# Altium schematic sheet RTC.SchDoc
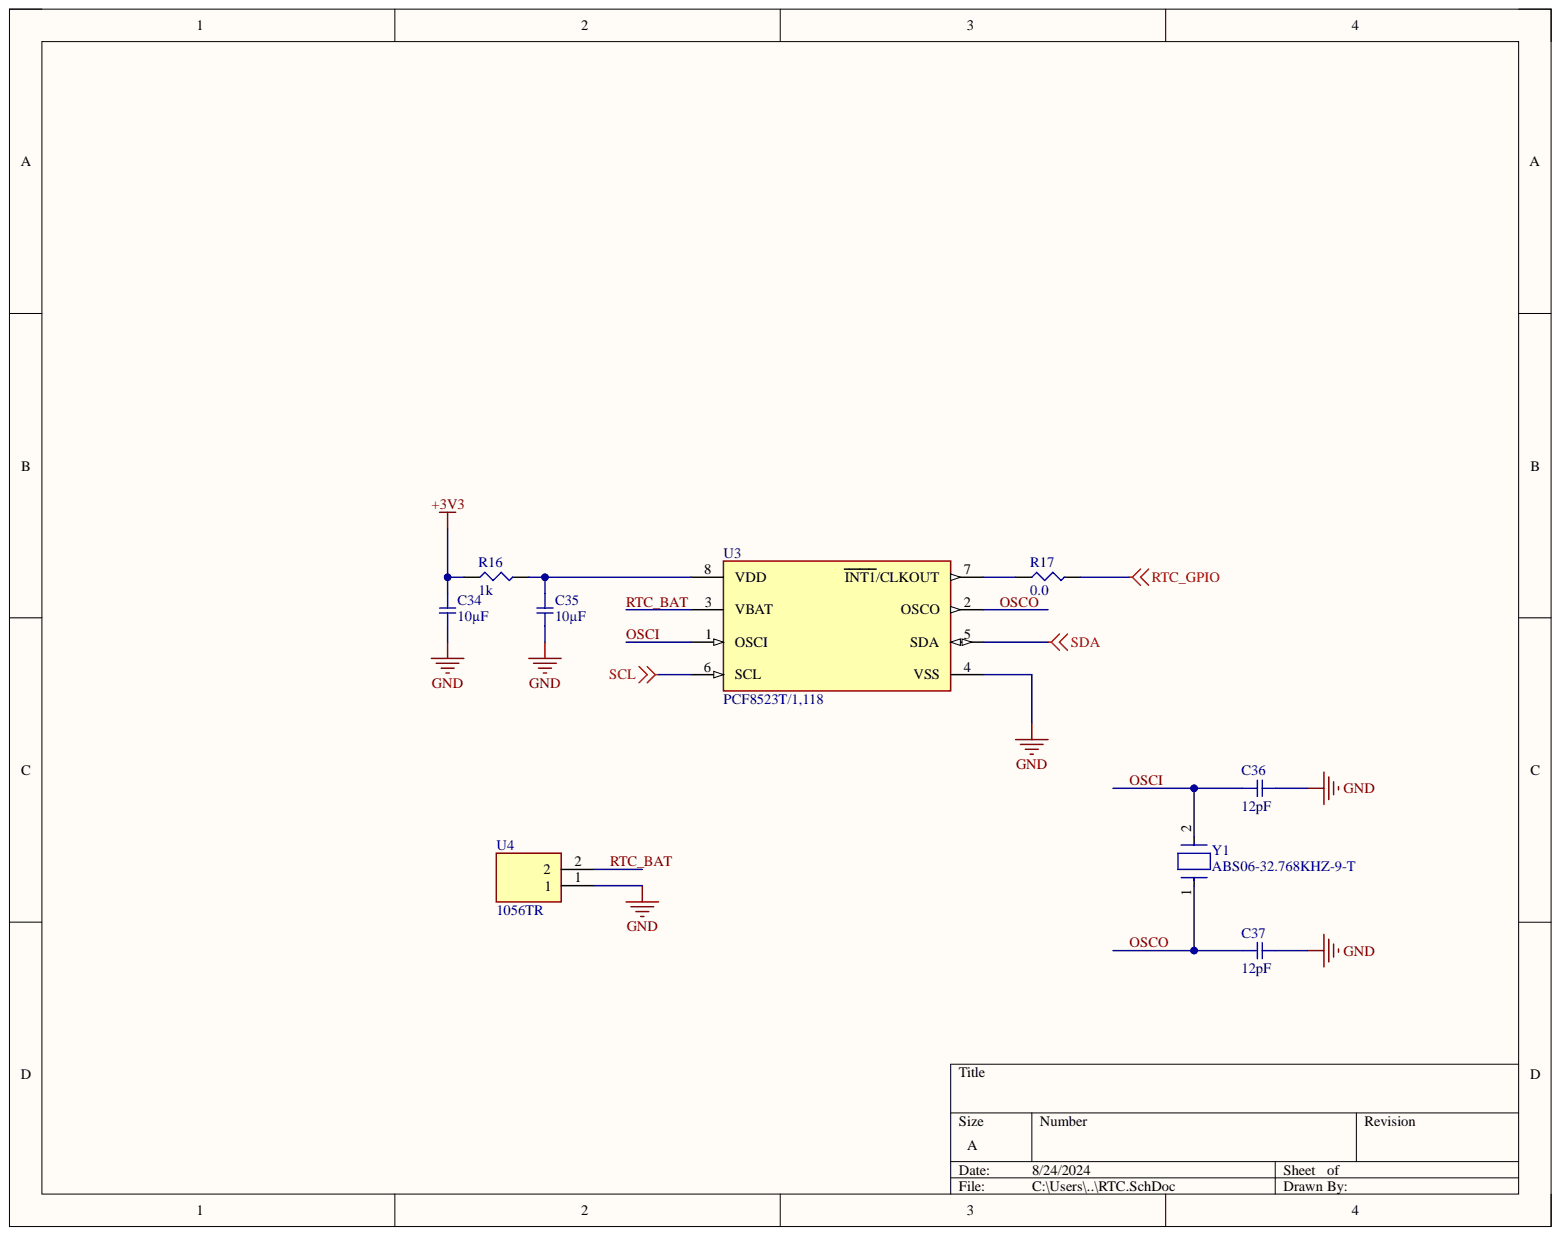
[diagram p0: RTC.SchDoc]

## Parts
C34  10µF  [SMD-0402C]
C35  10µF  [SMD-0402C]
C36  12pF  [SMD-0402C]
C37  12pF  [SMD-0402C]
R16  1k  [SMD-0402-RES]
R17  0.0  [SMD-0402-RES]
U3  PCF8523T/1,118  [FP-SOT96-1-IPC_B]
U4  1056TR  [1056TR]
Y1  ABS06-32.768KHZ-9-T  [FP-ABS06-MFG]

## Nets
+3V3: C34.1 R16.1
GND: C34.2 C35.2 C36.2 C37.2 U3.4(VSS) U4.1
RTC_GPIO: R17.2
SCL: U3.6(SCL)
SDA: U3.5(SDA)
OSCI: C36.1 U3.1(OSCI) Y1.2
OSCO: C37.1 U3.2(OSCO) Y1.1
RTC_BAT: U3.3(VBAT) U4.2
NetC35_1: C35.1 R16.2 U3.8(VDD)
NetR17_1: R17.1 U3.7(INT1/CLKOUT)

## Library data of the parts
C34 C35 = GRJ155R60J106ME11D: CAP CER 10UF 6.3V X5R 0402; Manufacturer: Murata Electronics North America; @ qty: 0; Minimum Quantity: 1; Tolerance: ±20%; Unit Price (USD): 0.23; Applications: Boardflex Sensitive; Component Kind: Standard; Datasheets: http://psearch.en.murata.com/capacitor/product/GRJ155R60J106ME11%23.pdf; Digi-Key Part Number: 490-13211-1-ND; Factory Stock: 0; Features: Derating Recommended, Soft Termination; Height - Seated (Max): -; Image: //media.digikey.com/Renders/Murata%20Renders/ZRB-SERIES-0402.jpg; Lead Spacing: -; Lead Style: -; Library Name: capacitor_murata_0402.IntLib; Mounting Type: Surface Mount, MLCC; Operating Temperature: -55°C ~ 85°C; Package / Case: 0402 (1005 Metric); Packaging: Cut Tape (CT); Part Number: GRJ155R60J106ME11D; Part Status: Active; Quantity Available: 64064; Ratings: -; Series: GRJ; Size / Dimension: 0.039" L x 0.020" W (1.00mm x 0.50mm); Temperature Coefficient: X5R; Thickness (Max): 0.028" (0.70mm); Value: 10µF; Voltage: 6.3V
C36 C37 = GCM1555C1H120JA16D: CAP CER 12PF 50V NP0 0402; Manufacturer: Murata Electronics North America; @ qty: 0; Minimum Quantity: 1; Temperature Coefficient: C0G, NP0; Tolerance: ±5%; Unit Price (USD): 0.1; Applications: Automotive; Component Kind: Standard; Datasheets: http://www.murata.com/~/media/webrenewal/support/library/catalog/products/capacitor/mlcc/c03e.ashx; Digi-Key Part Number: 490-12649-1-ND; Factory Stock: 0; Features: -; Height - Seated (Max): -; Image: //media.digikey.com/Renders/Murata%20Renders/ZRB-SERIES-0402.jpg; Lead Spacing: -; Lead Style: -; Library Name: capacitor_murata_0402.IntLib; Mounting Type: Surface Mount, MLCC; Operating Temperature: -55°C ~ 125°C; Package / Case: 0402 (1005 Metric); Packaging: Cut Tape (CT); Part Number: GCM1555C1H120JA16D; Part Status: Active; Quantity Available: 29045; Ratings: AEC-Q200; Series: GCM; Size / Dimension: 0.039" L x 0.020" W (1.00mm x 0.50mm); Thickness (Max): 0.022" (0.55mm); Value: 12pF; Voltage: 50V
R16 = ERJ-2GEJ102X: RES SMD 1K OHM 5% 1/10W 0402; Manufacturer: Panasonic Electronic Components; @ qty: 1500; Factory Stock: 0; Minimum Quantity: 1; Power (Watts): 0.1W, 1/10W; Temperature Coefficient: ±200ppm/°C; Unit Price (USD): 0.00350; Component Kind: Standard; Composition: Thick Film; Datasheets: http://industrial.panasonic.com/www-cgi/jvcr13pz.cgi?E+PZ+3+AOA0001+ERJ2GEJ102X+7+WW; Digi-Key Part Number: P1.0KJCT-ND; Failure Rate: -; Features: Automotive AEC-Q200; Height - Seated (Max): 0.016" (0.40mm); Image: //media.digikey.com/Photos/Panasonic%20Photos/ERJ-PA2-Series.jpg; Library Name: Panasonic_ERJ_0402.IntLib; Number of Terminations: 2; Operating Temperature: -55°C ~ 155°C; Package / Case: 0402 (1005 Metric); Packaging: Cut Tape (CT); Part Number: ERJ-2GEJ102X; Part Status: Active; Quantity Available: 1939857; Series: ERJ; Size / Dimension: 0.039" L x 0.020" W (1.00mm x 0.50mm); Supplier Device Package: 0402; Tolerance: ±5%; Value: 1k
R17 = ERJ-2GE0R00X: RES SMD 0.0OHM JUMPER 1/10W 0402; Manufacturer: Panasonic Electronic Components; @ qty: 1500; Factory Stock: 0; Minimum Quantity: 1; Power (Watts): 0.1W, 1/10W; Unit Price (USD): 0.00384; Component Kind: Standard; Composition: Thick Film; Datasheets: http://industrial.panasonic.com/www-cgi/jvcr13pz.cgi?E+PZ+3+AOA0001+ERJ2GE0R00X+7+WW; Digi-Key Part Number: P0.0JCT-ND; Failure Rate: -; Features: Automotive AEC-Q200; Height - Seated (Max): 0.016" (0.40mm); Image: //media.digikey.com/Photos/Panasonic%20Photos/ERJ-PA2-Series.jpg; Library Name: Panasonic_ERJ_0402.IntLib; Number of Terminations: 2; Operating Temperature: -55°C ~ 155°C; Package / Case: 0402 (1005 Metric); Packaging: Cut Tape (CT); Part Number: ERJ-2GE0R00X; Part Status: Active; Quantity Available: 3152483; Series: ERJ; Size / Dimension: 0.039" L x 0.020" W (1.00mm x 0.50mm); Supplier Device Package: 0402; Temperature Coefficient: -; Tolerance: Jumper; Value: 0.0
U3 = CMP-10083-000014-1: IC RTC CLK/CALENDAR I2C 8-SOIC; Logic Function: Clock; Max Supply Voltage: 5.5V; Min Supply Voltage: 1.2V; Time Format: HH:MM:SS; Case/Package: SO; Component Kind: Standard; Contact Plating: Gold; Interface: I2C; Lead Free: Lead Free; Library Name: Altium Content Vault; Max Operating Temperature: 85°C; Min Operating Temperature: -40°C; Mount: Surface Mount; Number of Pins: 8; Package Quantity: 2500; Packaging: Tape and Reel; Radiation Hardening: No; REACH SVHC: No SVHC
U4 = 1056TR: Undefined or Miscellaneous; Manufacturer_Name: Keystone Electronics; Component Kind: Standard; Datasheet Link: http://www.keyelco.com/product-pdf.cfm?p=4028; Manufacturer_Part_Number: 1056TR; Mouser Part Number: 534-1056TR; Mouser Price/Stock: https://www.mouser.co.uk/ProductDetail/Keystone-Electronics/1056TR?qs=sZfk%252BfAlGe6t6AyLJNcPdQ%3D%3D
Y1 = CMP-27762-015421-1: Crystal 32.768kHz ±20ppm (Tol) 9pF FUND 90kΩ 2-Pin Mini-CSMD T/R; ESR (Equivalent Series Resistance): 90kR; Case/Package: CSMD; Component Kind: Standard; Depth: 1.2mm; Frequency: 32.768kHz; Frequency Tolerance: 20ppm; Height: 0.6mm; Lead Free: Lead Free; Length: 2mm; Library Name: Altium Content Vault; Load Capacitance: 9pF; Max Operating Temperature: 85°C; Min Operating Temperature: -40°C; Mount: Surface Mount; Number of Pins: 2; Package Quantity: 3000; Packaging: Tape and Reel; RoHS Compliant: Yes; Series: ABS06; Termination: SMD/SMT; Tolerance: 20ppm; Width: 1.2mm
